# Altium schematic sheet P13_M1_SLA_CHARGER.SchDoc(P13_M1_SLA_CHARGER)
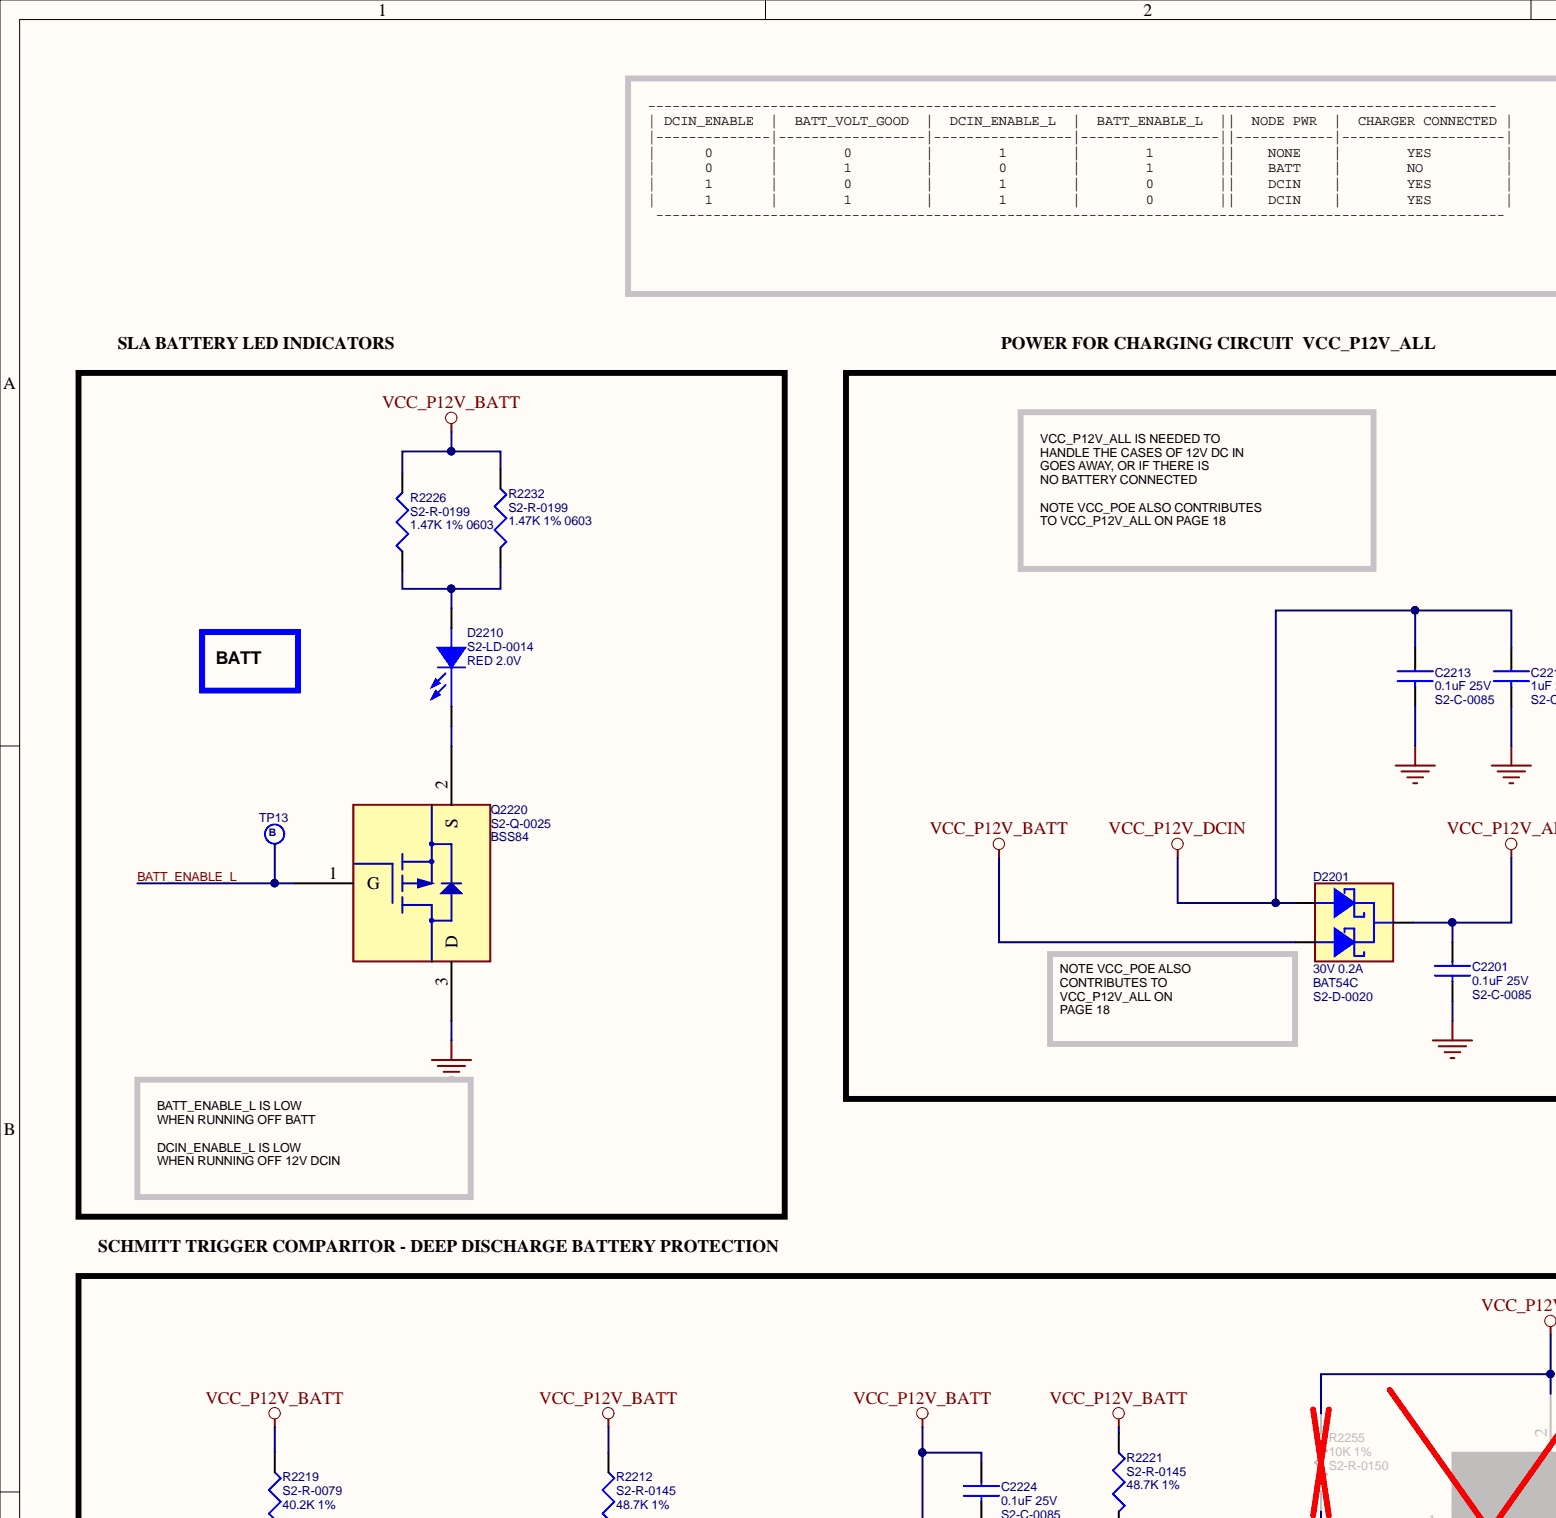
[diagram p0: P13_M1_SLA_CHARGER.SchDoc, top left]
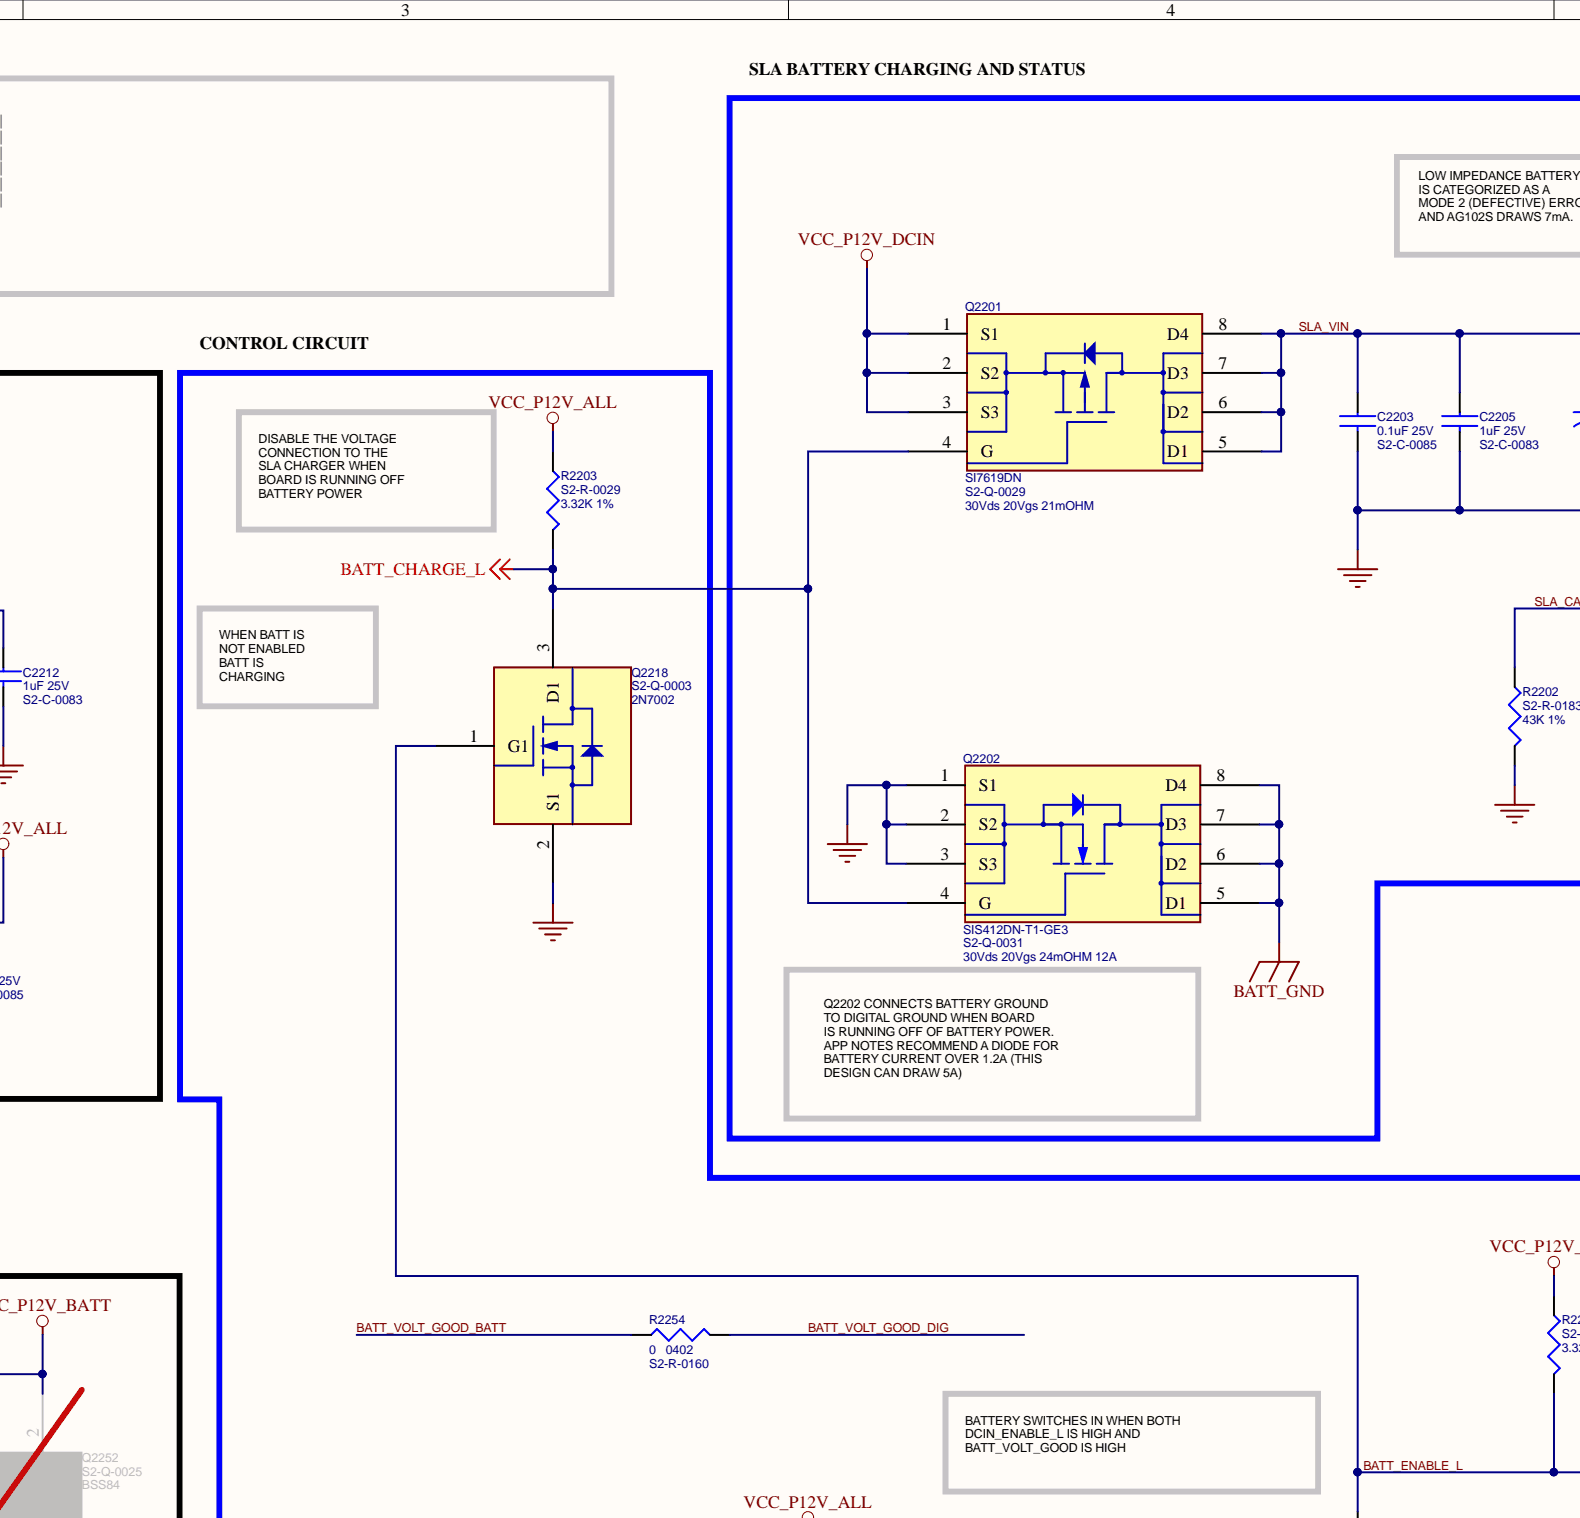
[diagram p1: P13_M1_SLA_CHARGER.SchDoc, top centre]
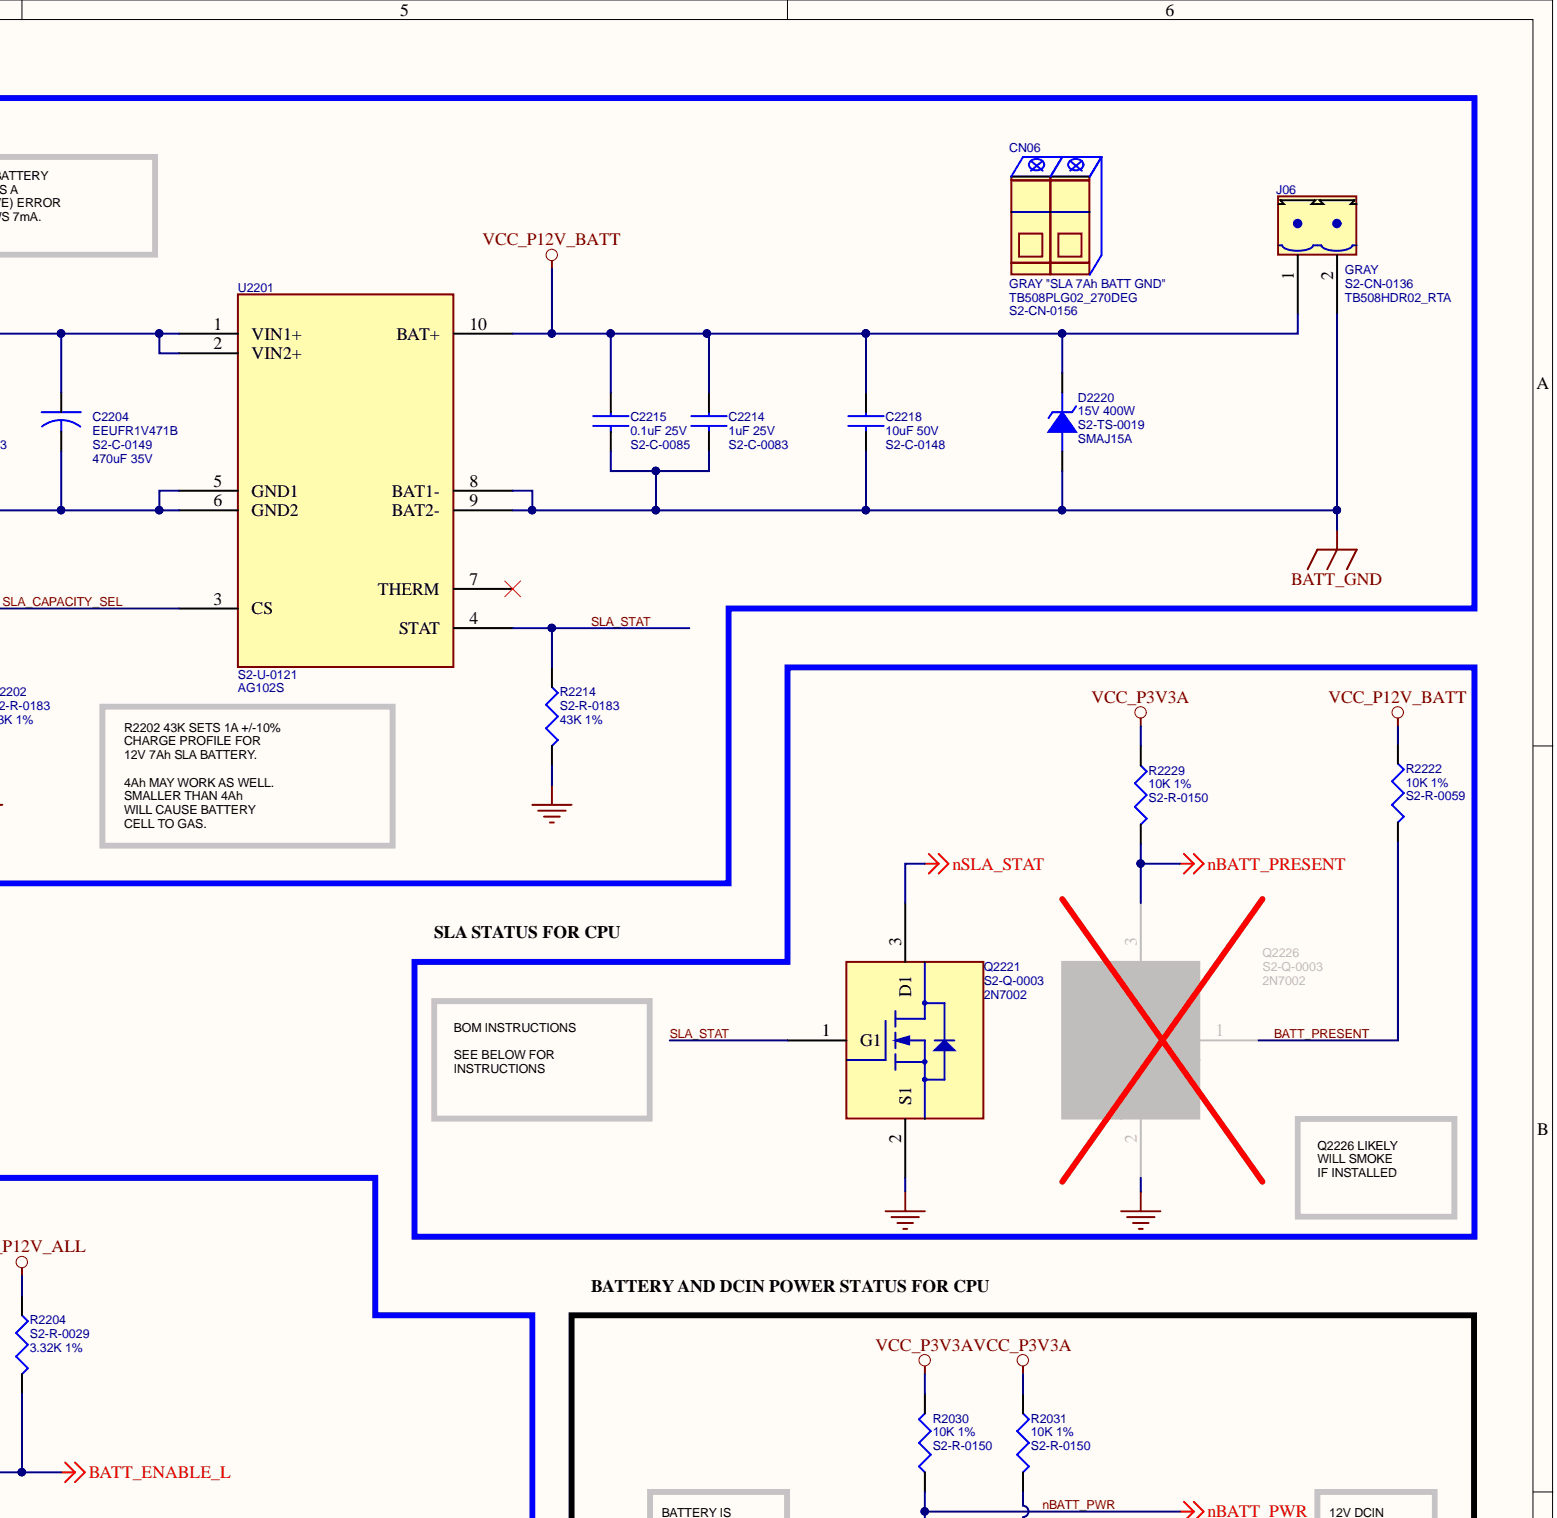
[diagram p2: P13_M1_SLA_CHARGER.SchDoc, top right]
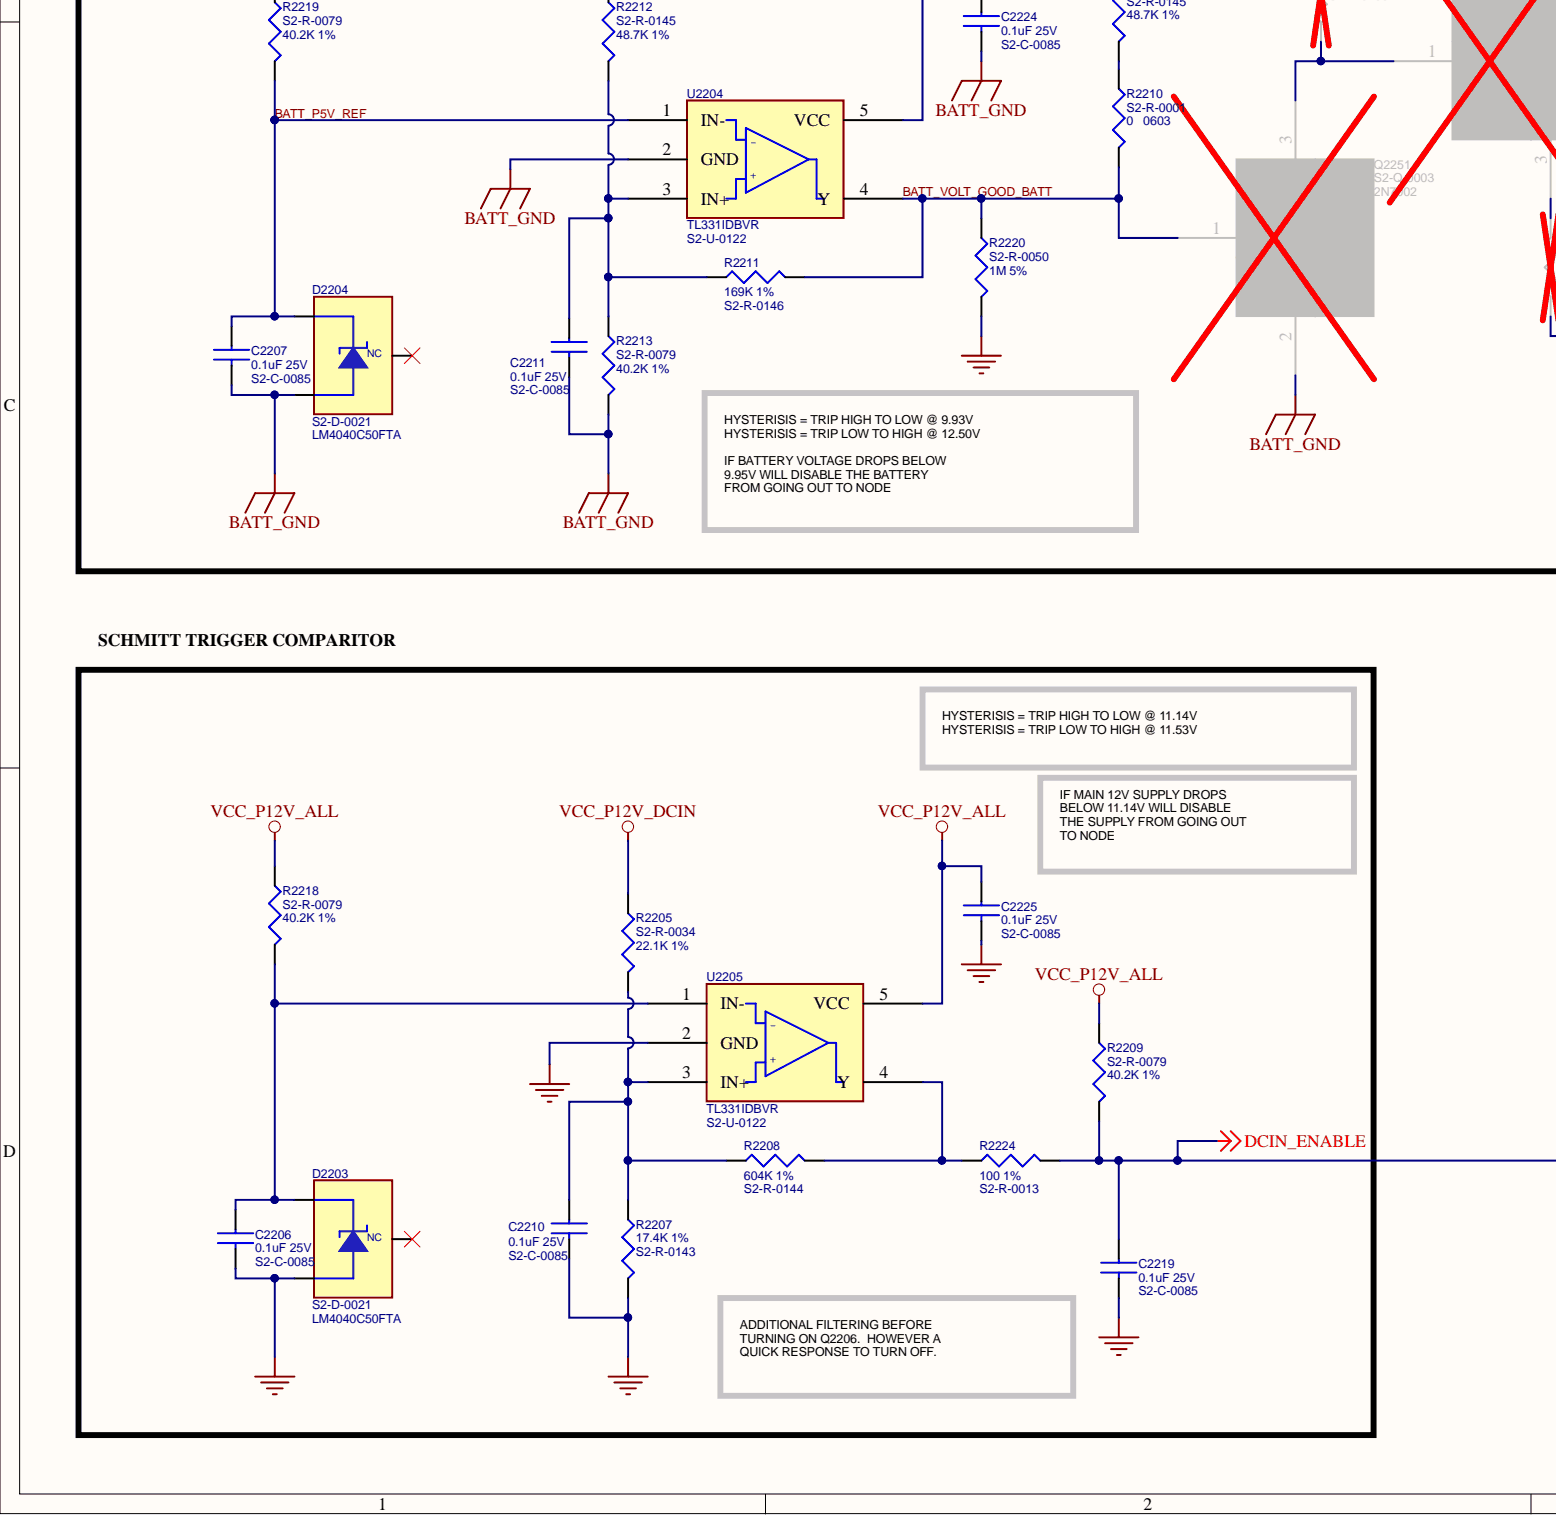
[diagram p3: P13_M1_SLA_CHARGER.SchDoc, bottom left]
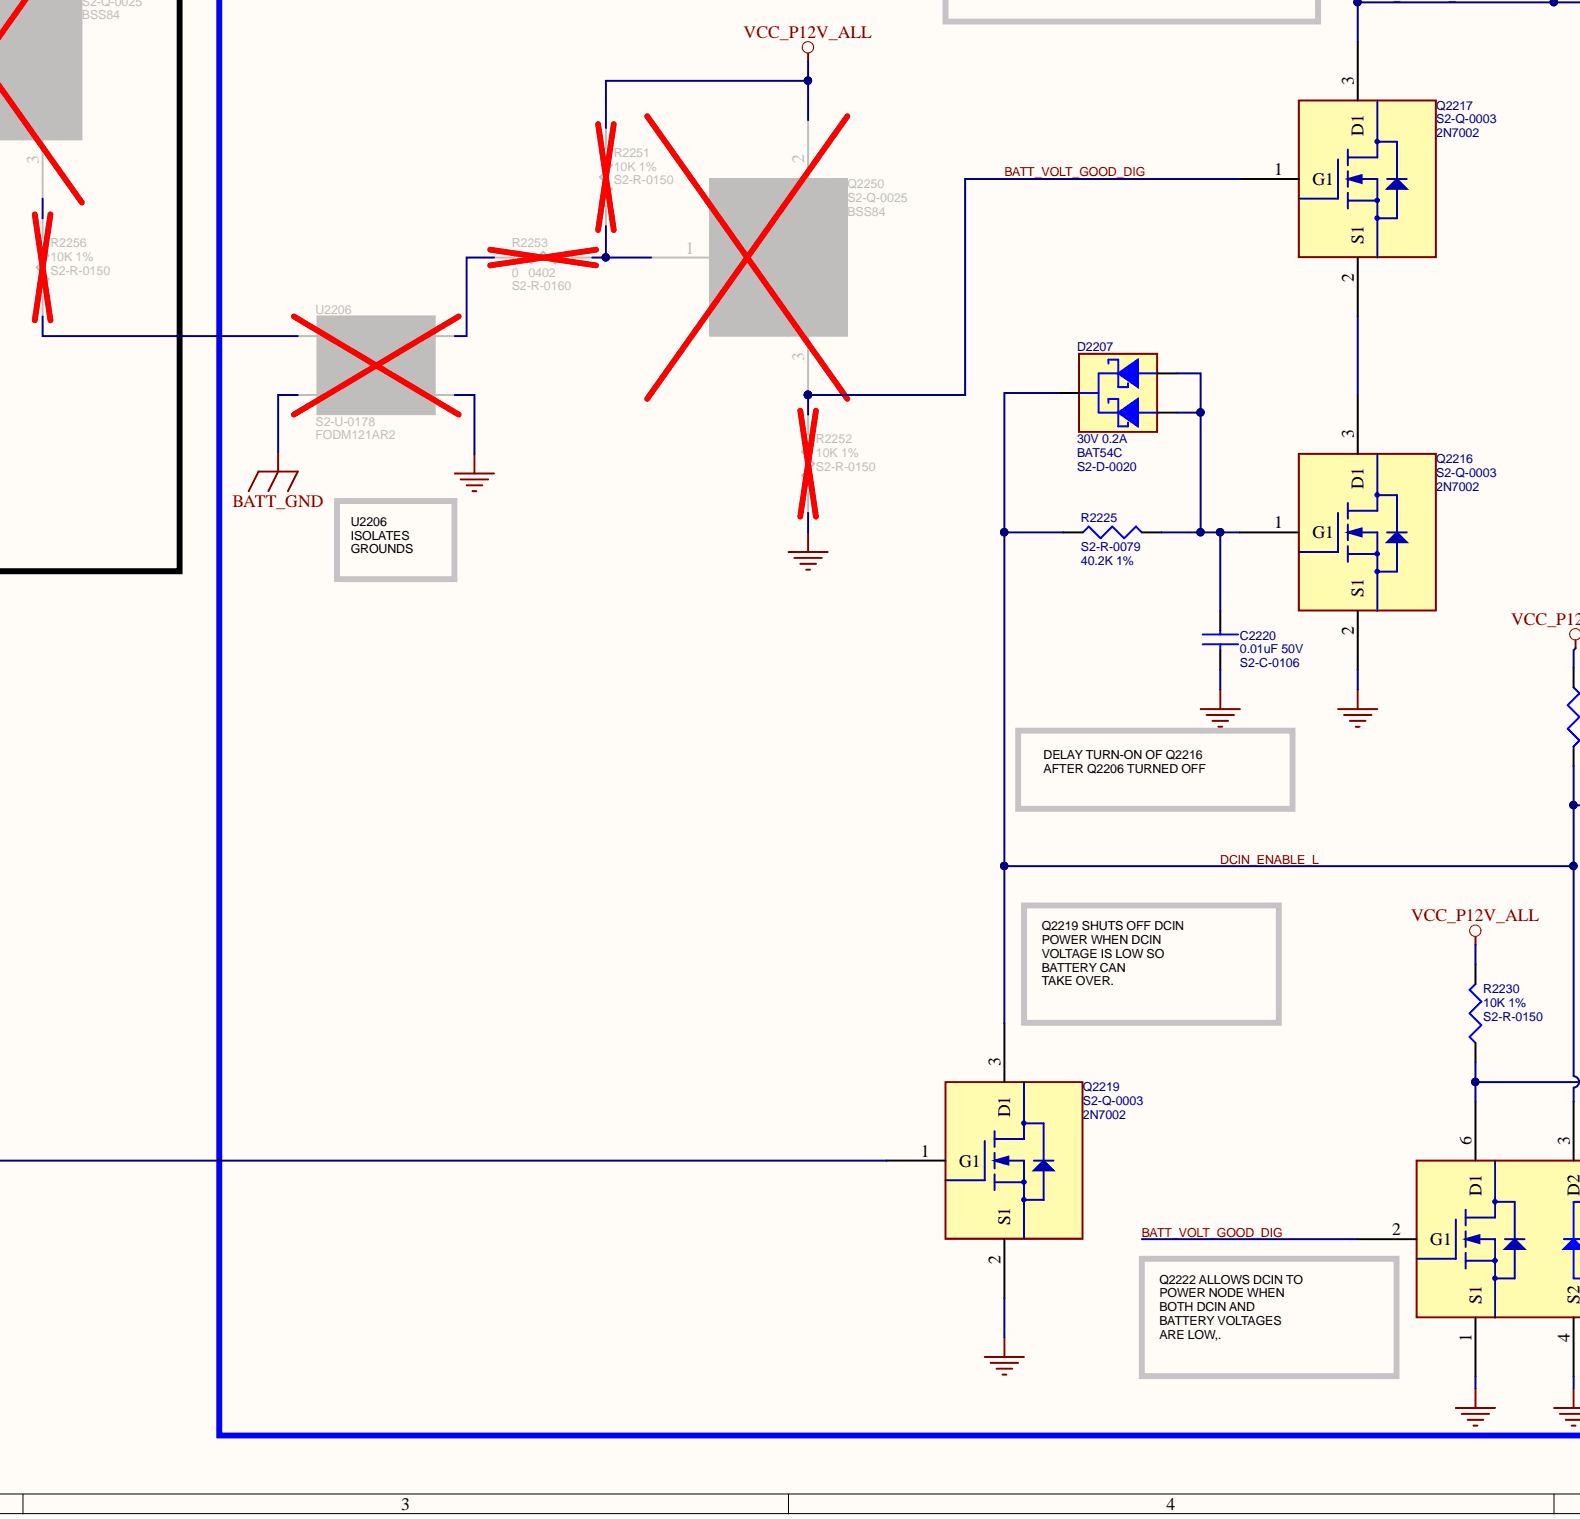
[diagram p4: P13_M1_SLA_CHARGER.SchDoc, bottom centre]
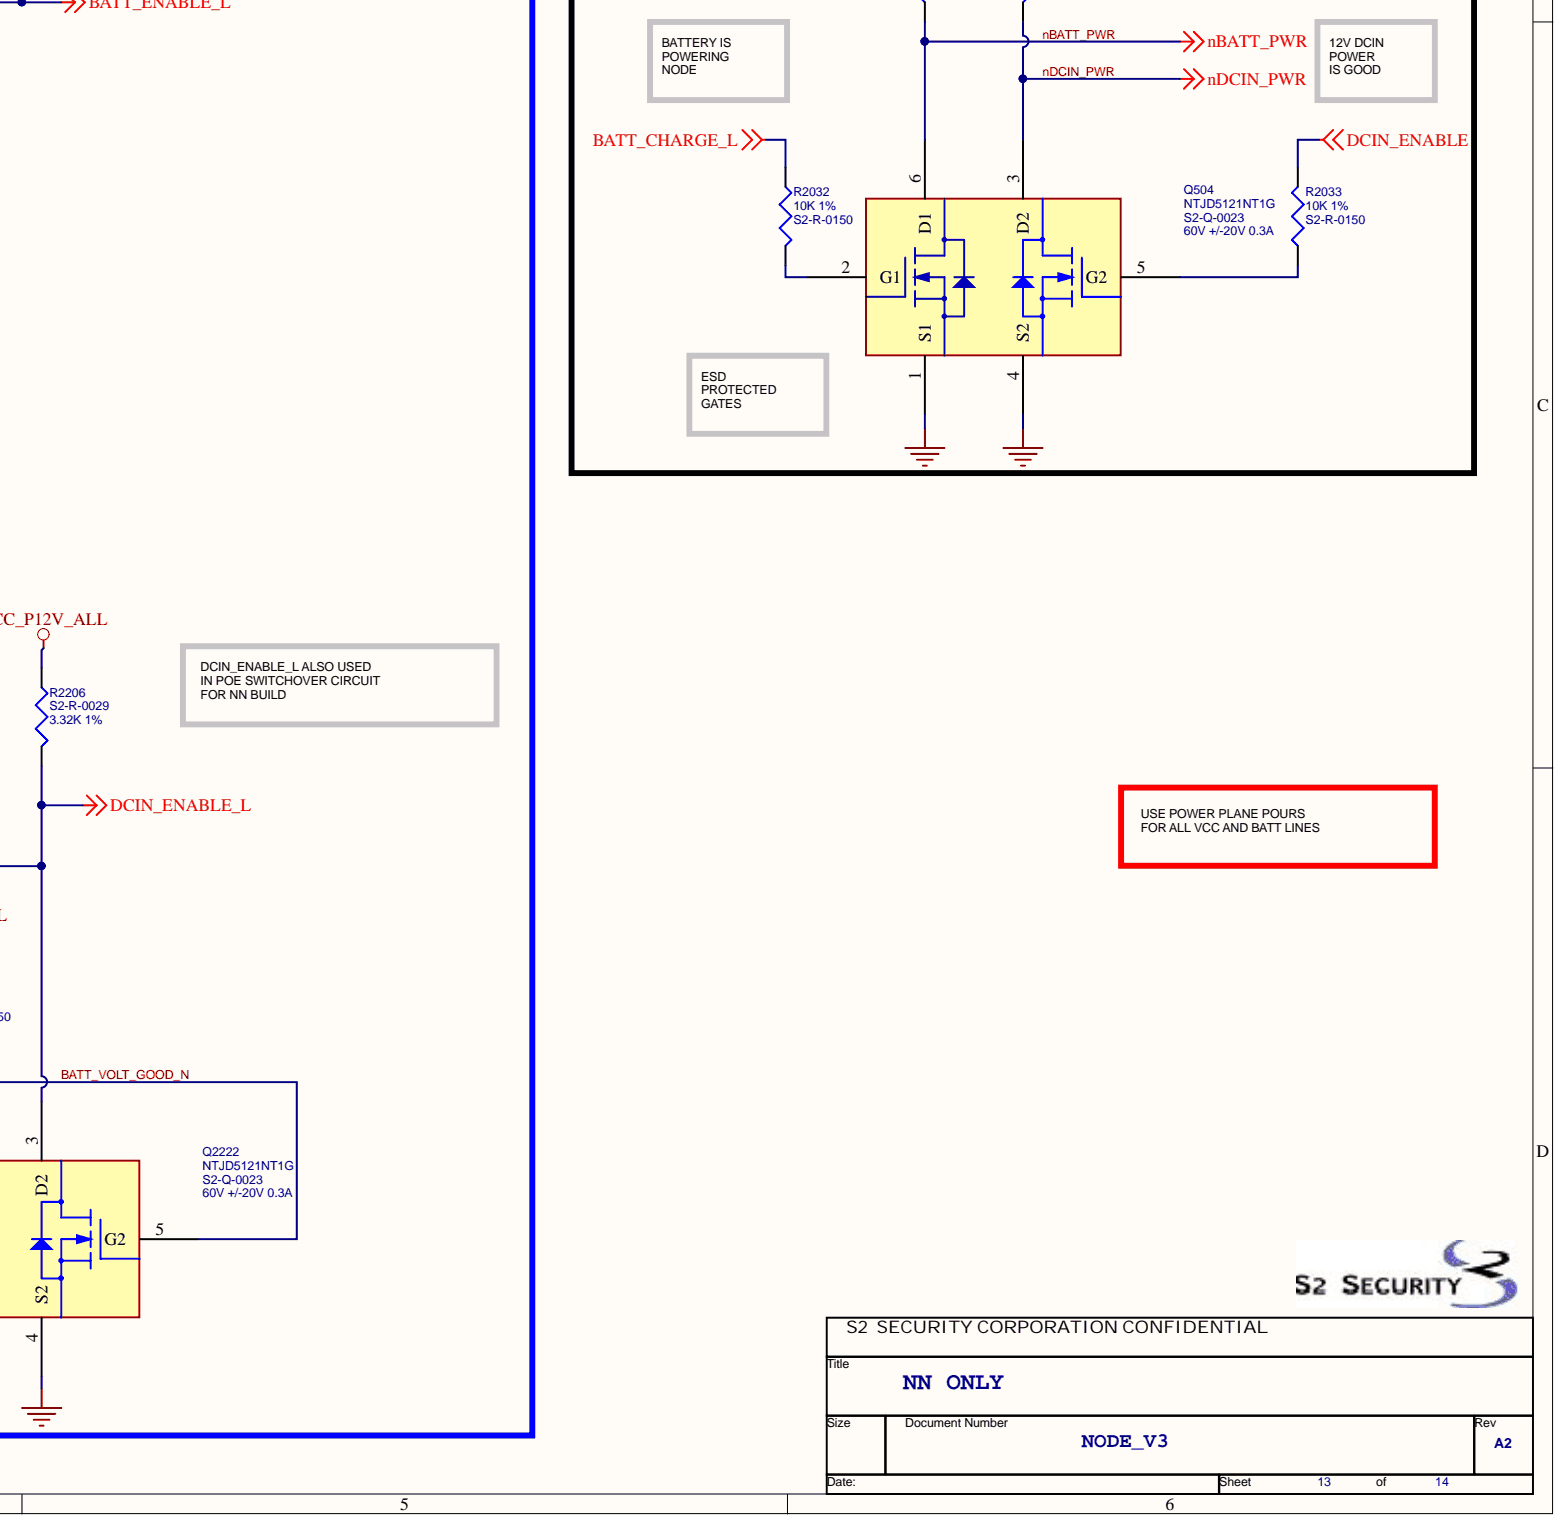
[diagram p5: P13_M1_SLA_CHARGER.SchDoc, bottom right]

## Parts
C2201  S2_PN: S2-C-0085  DESC: 0.1uF 25V  [CAPC1608X90N]  (hidden comment: C_0U1_25V_0603)
C2203  S2_PN: S2-C-0085  DESC: 0.1uF 25V  [CAPC1608X90N]  (hidden comment: C_0U1_25V_0603)
C2204  S2_PN: S2-C-0149  ATTR2: EEUFR1V471B  [CAP_EEU-FR1V471B]  (hidden comment: C_ALUM_470U_35V_RAD_5MM)
C2205  S2_PN: S2-C-0083  DESC: 1uF 25V  [CAPC1608X90N]  (hidden comment: C_1U_25V_0603)
C2206  S2_PN: S2-C-0085  DESC: 0.1uF 25V  [CAPC1608X90N]  (hidden comment: C_0U1_25V_0603)
C2207  S2_PN: S2-C-0085  DESC: 0.1uF 25V  [CAPC1608X90N]  (hidden comment: C_0U1_25V_0603)
C2210  S2_PN: S2-C-0085  DESC: 0.1uF 25V  [CAPC1608X90N]  (hidden comment: C_0U1_25V_0603)
C2211  S2_PN: S2-C-0085  DESC: 0.1uF 25V  [CAPC1608X90N]  (hidden comment: C_0U1_25V_0603)
C2212  S2_PN: S2-C-0083  DESC: 1uF 25V  [CAPC1608X90N]  (hidden comment: C_1U_25V_0603)
C2213  S2_PN: S2-C-0085  DESC: 0.1uF 25V  [CAPC1608X90N]  (hidden comment: C_0U1_25V_0603)
C2214  S2_PN: S2-C-0083  DESC: 1uF 25V  [CAPC1608X90N]  (hidden comment: C_1U_25V_0603)
C2215  S2_PN: S2-C-0085  DESC: 0.1uF 25V  [CAPC1608X90N]  (hidden comment: C_0U1_25V_0603)
C2218  S2_PN: S2-C-0148  DESC: 10uF 50V  [CAPC3216X180N]  (hidden comment: C_10U_50V_0805)
C2219  S2_PN: S2-C-0085  DESC: 0.1uF 25V  [CAPC1608X90N]  (hidden comment: C_0U1_25V_0603)
C2220  S2_PN: S2-C-0106  [CAPC1608X90N]  (hidden comment: C_0U01_50V_0603)
C2224  S2_PN: S2-C-0085  DESC: 0.1uF 25V  [CAPC1608X90N]  (hidden comment: C_0U1_25V_0603)
C2225  S2_PN: S2-C-0085  DESC: 0.1uF 25V  [CAPC1608X90N]  (hidden comment: C_0U1_25V_0603)
CN06  S2_PN: S2-CN-0156  DESC: TB508PLG02_270DEG  ATTR2: GRAY "SLA 7Ah BATT GND"  [N/A]  (hidden comment: CN_5MM08_02PLUG_270DEG_GRAY_SLA)
D2201  S2_PN: S2-D-0020  DESC: BAT54C  ATTR2: 30V 0.2A  [SOT95P310X140-3N]  (hidden comment: D_BAT54C_SCHOTTKY_DUAL_30V_0A2)
D2203  S2_PN: S2-D-0021  DESC: LM4040C50FTA  [SOT95P310X140-3N]  (hidden comment: D_LM4040C50FTA_SHUNT_REF_5V)
D2204  S2_PN: S2-D-0021  DESC: LM4040C50FTA  [SOT95P310X140-3N]  (hidden comment: D_LM4040C50FTA_SHUNT_REF_5V)
D2207  S2_PN: S2-D-0020  DESC: BAT54C  ATTR2: 30V 0.2A  [SOT95P310X140-3N]  (hidden comment: D_BAT54C_SCHOTTKY_DUAL_30V_0A2)
D2210  S2_PN: S2-LD-0014  ATTR: RED 2.0V  [LED_0805]  (hidden comment: LED_0805_RED)
D2220  S2_PN: S2-TS-0019  DESC: SMAJ15A  ATTR2: 15V 400W  [DOIM5026x229N]  (hidden comment: D_SMAJ15A_TVS_15V_400W)
J06  S2_PN: S2-CN-0136  PKG: TB508HDR02_RTA  ATTR2: GRAY  [Conn_5433668]  (hidden comment: CN_5MM08_02POS_HEADER_RTA_GRAY)
Q504  S2_PN: S2-Q-0023  DESC: NTJD5121NT1G  [SOT65x210x140x110-6N]  (hidden comment: Q_DUAL_NFET_NTJD5121N)
Q2201  S2_PN: S2-Q-0029  DESC: SI7619DN  ATTR2: 30Vds 20Vgs 21mOHM  [POWERPAK_1212X66X340X112X8N]  (hidden comment: Q_POWER_PFET_SI7619DN)
Q2202  S2_PN: S2-Q-0031  DESC: SIS412DN-T1-GE3  ATTR2: 30Vds 20Vgs 24mOHM 12A  [PowerPAK_330X330X120_COMMON]  (hidden comment: Q_POWER_NFET_SIS412DN)
Q2216  S2_PN: S2-Q-0003  DESC: 2N7002  [SOT95P310X140-3N]  (hidden comment: Q_NFET_2N7002)
Q2217  S2_PN: S2-Q-0003  DESC: 2N7002  [SOT95P310X140-3N]  (hidden comment: Q_NFET_2N7002)
Q2218  S2_PN: S2-Q-0003  DESC: 2N7002  [SOT95P310X140-3N]  (hidden comment: Q_NFET_2N7002)
Q2219  S2_PN: S2-Q-0003  DESC: 2N7002  [SOT95P310X140-3N]  (hidden comment: Q_NFET_2N7002)
Q2220  S2_PN: S2-Q-0025  DESC: BSS84  [SOT95P310X140-3N]  (hidden comment: Q_PFET_BSS84)
Q2221  S2_PN: S2-Q-0003  DESC: 2N7002  [SOT95P310X140-3N]  (hidden comment: Q_NFET_2N7002)
Q2222  S2_PN: S2-Q-0023  DESC: NTJD5121NT1G  ATTR2: 60V +/-20V 0.3A  [SOT65x210x140x110-6N]  (hidden comment: Q_DUAL_NFET_NTJD5121N)
Q2226  S2_PN: S2-Q-0003  DESC: 2N7002  [SOT95P310X140-3N]  (hidden comment: Q_NFET_2N7002)
Q2250  S2_PN: S2-Q-0025  DESC: BSS84  [SOT95P310X140-3N]  (hidden comment: Q_PFET_BSS84)
Q2251  S2_PN: S2-Q-0003  DESC: 2N7002  [SOT95P310X140-3N]  (hidden comment: Q_NFET_2N7002)
Q2252  S2_PN: S2-Q-0025  DESC: BSS84  [SOT95P310X140-3N]  (hidden comment: Q_PFET_BSS84)
R2030  S2_PN: S2-R-0150  DESC: 10K 1%  [RESC1005X40N]  (hidden comment: R_10K_0402)
R2031  S2_PN: S2-R-0150  DESC: 10K 1%  [RESC1005X40N]  (hidden comment: R_10K_0402)
R2032  S2_PN: S2-R-0150  DESC: 10K 1%  [RESC1005X40N]  (hidden comment: R_10K_0402)
R2033  S2_PN: S2-R-0150  DESC: 10K 1%  [RESC1005X40N]  (hidden comment: R_10K_0402)
R2202  S2_PN: S2-R-0183  DESC: 43K 1%  [RESC1608X55N]  (hidden comment: R_43K_0603)
R2203  S2_PN: S2-R-0029  DESC: 3.32K 1%  [RESC1608X55N]  (hidden comment: R_3K32_0603)
R2204  S2_PN: S2-R-0029  DESC: 3.32K 1%  [RESC1608X55N]  (hidden comment: R_3K32_0603)
R2205  S2_PN: S2-R-0034  DESC: 22.1K 1%  [RESC1608X55N]  (hidden comment: R_22K1_0603)
R2206  S2_PN: S2-R-0029  DESC: 3.32K 1%  [RESC1608X55N]  (hidden comment: R_3K32_0603)
R2207  S2_PN: S2-R-0143  DESC: 17.4K 1%  [RESC1608X55N]  (hidden comment: R_17K4_0603)
R2208  S2_PN: S2-R-0144  DESC: 604K 1%  [RESC1608X55N]  (hidden comment: R_604K_0603)
R2209  S2_PN: S2-R-0079  DESC: 40.2K 1%  [RESC1608X55N]  (hidden comment: R_40K2_0603)
R2210  S2_PN: S2-R-0001  [RESC1608X55N]  (hidden comment: R_0_0603)
R2211  S2_PN: S2-R-0146  DESC: 169K 1%  [RESC1608X55N]  (hidden comment: R_169K_0603)
R2212  S2_PN: S2-R-0145  DESC: 48.7K 1%  [RESC1608X55N]  (hidden comment: R_48K7_0603)
R2213  S2_PN: S2-R-0079  DESC: 40.2K 1%  [RESC1608X55N]  (hidden comment: R_40K2_0603)
R2214  S2_PN: S2-R-0183  DESC: 43K 1%  [RESC1608X55N]  (hidden comment: R_43K_0603)
R2218  S2_PN: S2-R-0079  DESC: 40.2K 1%  [RESC1608X55N]  (hidden comment: R_40K2_0603)
R2219  S2_PN: S2-R-0079  DESC: 40.2K 1%  [RESC1608X55N]  (hidden comment: R_40K2_0603)
R2220  S2_PN: S2-R-0050  DESC: 1M 5%  [RESC1608X55N]  (hidden comment: R_1M_0603_5percent)
R2221  S2_PN: S2-R-0145  DESC: 48.7K 1%  [RESC1608X55N]  (hidden comment: R_48K7_0603)
R2222  S2_PN: S2-R-0059  DESC: 10K 1%  [RESC1608X55N]  (hidden comment: R_10K_0603)
R2224  S2_PN: S2-R-0013  DESC: 100 1%  [RESC1608X55N]  (hidden comment: R_100_0603)
R2225  S2_PN: S2-R-0079  DESC: 40.2K 1%  [RESC1608X55N]  (hidden comment: R_40K2_0603)
R2226  S2_PN: S2-R-0199  [RESC1608X55N]  (hidden comment: R_1K47_0603)
R2229  S2_PN: S2-R-0150  DESC: 10K 1%  [RESC1005X40N]  (hidden comment: R_10K_0402)
R2230  S2_PN: S2-R-0150  DESC: 10K 1%  [RESC1005X40N]  (hidden comment: R_10K_0402)
R2232  S2_PN: S2-R-0199  DESC: 1.47K 1% 0603  [RESC1608X55N]  (hidden comment: R_1K47_0603)
R2251  S2_PN: S2-R-0150  DESC: 10K 1%  [RESC1005X40N]  (hidden comment: R_10K_0402)
R2252  S2_PN: S2-R-0150  DESC: 10K 1%  [RESC1005X40N]  (hidden comment: R_10K_0402)
R2253  S2_PN: S2-R-0160  DESC: 0   0402  [RESC1005X40N]  (hidden comment: R_0_0402)
R2254  S2_PN: S2-R-0160  DESC: 0   0402  [RESC1005X40N]  (hidden comment: R_0_0402)
R2255  S2_PN: S2-R-0150  DESC: 10K 1%  [RESC1005X40N]  (hidden comment: R_10K_0402)
R2256  S2_PN: S2-R-0150  DESC: 10K 1%  [RESC1005X40N]  (hidden comment: R_10K_0402)
TP13  TP_SMT_TEST_POINT_BOTTOM  [TP35_SMT]  (value not drawn)
U2201  S2_PN: S2-U-0121  DESC: AG102S  [CONN_AG102S]  (hidden comment: U_AG102S_SLA_CHARGER)
U2204  DESC: TL331IDBVR  [SOT95P280X145-5N]  (hidden comment: U_TL331IDBVR_DIFF_COMPARATOR)
U2205  DESC: TL331IDBVR  [SOT95P280X145-5N]  (hidden comment: U_TL331IDBVR_DIFF_COMPARATOR)
U2206  S2_PN: S2-U-0178  DESC: FODM121AR2  [Optocoupler_FODM121AR2]  (hidden comment: U_PHOTOTRANS_OPTOCPL_FODM121AR2)

## Nets
BATT_CHARGE_L: Q2201.4(G) Q2202.4(G) Q2218.3 R2032.1 R2203.2
BATT_GND: C2207.2 C2211.2 C2214.2 C2215.2 C2218.2 C2224.2 D2204.2 D2220.1 J06.2 Q2202.5(D1) Q2202.6(D2) Q2202.7(D3) Q2202.8(D4) Q2251.2 R2213.2 U2201.8(BAT1-) U2201.9(BAT2-) U2204.2(GND) U2206.2
DCIN_ENABLE: C2219.1 Q2219.1 R2033.2 R2209.2 R2224.2
GND: C2201.2 C2203.2 C2204.2 C2205.2 C2206.2 C2210.2 C2212.2 C2213.2 C2219.2 C2220.2 C2225.2 D2203.2 Q504.1(S1) Q504.4(S2) Q2202.1(S1) Q2202.2(S2) Q2202.3(S3) Q2216.2 Q2218.2 Q2219.2 Q2220.3 Q2221.2 Q2222.1(S1) Q2222.4(S2) Q2226.2 R2202.2 R2207.2 R2214.2 R2220.2 R2252.2 U2201.5(GND1) U2201.6(GND2) U2205.2(GND) U2206.3
nBATT_PRESENT: Q2226.3 R2229.2
nSLA_STAT: Q2221.3
VCC_P3V3A: R2030.1 R2031.1 R2229.1
VCC_P12V_ALL: C2201.1 C2225.1 D2201.3 Q2250.2 R2203.1 R2204.1 R2206.1 R2209.1 R2218.1 R2230.1 R2251.1 U2205.5(VCC)
VCC_P12V_BATT: C2214.1 C2215.1 C2218.1 C2224.1 D2201.2 D2220.2 J06.1 Q2252.2 R2212.1 R2219.1 R2221.1 R2222.1 R2226.1 R2232.1 R2255.1 U2201.10(BAT+) U2204.5(VCC)
VCC_P12V_DCIN: C2212.1 C2213.1 D2201.1 Q2201.1(S1) Q2201.2(S2) Q2201.3(S3) R2205.1
BATT_ENABLE_L: Q2217.3 Q2218.1 Q2220.1 R2204.2 TP13.1
BATT_P5V_REF: C2207.1 D2204.1 R2219.2 U2204.1(IN-)
BATT_PRESENT: Q2226.1 R2222.2
BATT_VOLT_GOOD_BATT: Q2251.1 R2210.2 R2211.2 R2220.1 R2254.1 U2204.4(Y)
BATT_VOLT_GOOD_DIG: Q2217.1 Q2222.2(G1) Q2250.3 R2252.1 R2254.2
BATT_VOLT_GOOD_N: Q2222.5(G2) Q2222.6(D1) R2230.2
DCIN_ENABLE_L: D2207.3 Q2219.3 Q2222.3(D2) R2206.2 R2225.1
nBATT_PWR: Q504.6(D1) R2030.2
nDCIN_PWR: Q504.3(D2) R2031.2
SLA_CAPACITY_SEL: R2202.1 U2201.3(CS)
SLA_STAT: Q2221.1 R2214.1 U2201.4(STAT)
SLA_VIN: C2203.1 C2204.1 C2205.1 Q2201.5(D1) Q2201.6(D2) Q2201.7(D3) Q2201.8(D4) U2201.1(VIN1+) U2201.2(VIN2+)
NetC2206_1: C2206.1 D2203.1 R2218.2 U2205.1(IN-)
NetC2210_1: C2210.1 R2205.2 R2207.1 R2208.1 U2205.3(IN+)
NetC2211_1: C2211.1 R2211.1 R2212.2 R2213.1 U2204.3(IN+)
NetC2220_1: C2220.1 D2207.1 D2207.2 Q2216.1 R2225.2
NetD2210_1: D2210.1 R2226.2 R2232.2
NetD2210_2: D2210.2 Q2220.2
NetQ504_2: Q504.2(G1) R2032.2
NetQ504_5: Q504.5(G2) R2033.1
NetQ2216_3: Q2216.3 Q2217.2
NetQ2250_1: Q2250.1 R2251.2 R2253.2
NetQ2251_3: Q2251.3 Q2252.1 R2255.2
NetQ2252_3: Q2252.3 R2256.1
NetR2208_2: R2208.2 R2224.1 U2205.4(Y)
NetR2210_1: R2210.1 R2221.2
NetR2253_1: R2253.1 U2206.4
NetR2256_2: R2256.2 U2206.1

Not connected: D2203 3; D2204 3; U2201 7(THERM)

## Library data of the parts
C2201 C2203 C2206 C2207 C2210 C2211 C2213 C2215 C2219 C2224 C2225 = C_0U1_25V_0603: PKG: CC0603IPC; ATTR: X7R 10%; Library Name: NODE_V2_M1.SCHLIB; Component Kind: Standard
C2204 = C_ALUM_470U_35V_RAD_5MM: PKG: TH_DLS_10_17_5MM; DESC: 470uF 35V; ATTR: CAP AL 470UF 35V, 20%, 8000 HRS 105C TH, ESR=0.028; Library Name: NODE_V2_M1.SCHLIB; Component Kind: Standard
C2205 C2212 C2214 = C_1U_25V_0603: PKG: CC0603IPC; ATTR: X7R 10%; Library Name: NODE_V2_M1.SCHLIB; Component Kind: Standard
C2218 = C_10U_50V_0805: PKG: CC0805IPC; ATTR: X5R 10%; Library Name: NODE_V2_M1.SCHLIB; Component Kind: Standard
C2220 = C_0U01_50V_0603: PKG: CC0603IPC; DESC: 0.01uF 50V; ATTR: X7R 10%; Library Name: NODE_V2_M1.SCHLIB; Component Kind: Standard
CN06 = CN_5MM08_02PLUG_270DEG_GRAY_SLA: PKG: TB508PLUG02_180DEG; ATTR: PLUG TERM BLK 5.08MM 2POS 270DEG GRAY; Library Name: NODE_V2_M1.SCHLIB; Component Kind: Standard
D2201 D2207 = D_BAT54C_SCHOTTKY_DUAL_30V_0A2: PKG: SOT-23-3; ATTR: DIODE DUAL SCHOTTKY 30V 200 MA COMMON CATHODE; Library Name: NODE_V2_M1.SCHLIB; Component Kind: Standard
D2203 D2204 = D_LM4040C50FTA_SHUNT_REF_5V: PKG: SOT-23-321; ATTR: DIODE 5V SHUNT REFERENCE 0.5% 15mA SOT-23; Library Name: NODE_V2_M1.SCHLIB; Component Kind: Standard
D2210 = LED_0805_RED: DESC: LED 0805 SUPER RED 2.0V 54MCD; PKG: LED_0805; Library Name: NODE_V2_M1.SCHLIB; Component Kind: Standard
D2220 = D_SMAJ15A_TVS_15V_400W: PKG: SMA; ATTR: TVS UNI-DIR 15V 400W; Library Name: NODE_V2_M1.SCHLIB; Component Kind: Standard
J06 = CN_5MM08_02POS_HEADER_RTA_GRAY: ATTR: HEADER TERM BLK 5.08MM 2POS RTA GRAY; Library Name: NODE_V2_M1.SCHLIB; DESC: TB508HDR02_RTA; Component Kind: Standard
Q504 = Q_DUAL_NFET_NTJD5121N: PKG: SC-88; ATTR2: 60V +/-20V 0.3A; ATTR: MOSFET DUAL N-CHAN 60V +/-20V 0.3A; Library Name: NODE_V2_M1.SCHLIB; Component Kind: Standard
Q2201 = Q_POWER_PFET_SI7619DN: PKG: PowerPAK_1212-8; ATTR: MOSFET P-CH 21mOHM 30V 24A PowerPAK 1212-8; Library Name: NODE_V2_M1.SCHLIB; Component Kind: Standard
Q2202 = Q_POWER_NFET_SIS412DN: PKG: PowerPAK_1212-8; ATTR: MOSFET N-CH 24mOHM 30V 12A PowerPAK 1212-8; Library Name: NODE_V2_M1.SCHLIB; Component Kind: Standard
Q2216-Q2219 Q2221 Q2226 Q2251 = Q_NFET_2N7002: PKG: SOT-23; ATTR: MOSFET N-CHAN 60V 7.5OHM; Library Name: NODE_V2_M1.SCHLIB; Component Kind: Standard
Q2220 Q2250 Q2252 = Q_PFET_BSS84: PKG: SOT-23; ATTR2: -50V 0.13A 10OHM; ATTR: MOSFET P-CH 10 OHM -50V 0.13A SOT-23; Library Name: NODE_V2_M1.SCHLIB; Component Kind: Standard
Q2222 = Q_DUAL_NFET_NTJD5121N: PKG: SC-88; ATTR: MOSFET DUAL N-CHAN 60V +/-20V 0.3A; Library Name: NODE_V2_M1.SCHLIB; Component Kind: Standard
R2030-R2033 R2229 R2230 R2251 R2252 R2255 R2256 = R_10K_0402: PKG: CC0402IPC; ATTR: 1/16W; Library Name: NODE_V2_M1.SCHLIB; Component Kind: Standard
R2202 R2214 = R_43K_0603: PKG: CC0603IPC; ATTR: RES 43K OHM 1/10W 1% 0603; Library Name: NODE_V2_M1.SCHLIB; Component Kind: Standard
R2203 R2204 R2206 = R_3K32_0603: PKG: CC0603IPC; ATTR: RES 3.32K OHM 1/10W 1% 0603; Library Name: NODE_V2_M1.SCHLIB; Component Kind: Standard
R2205 = R_22K1_0603: PKG: CC0603IPC; ATTR: RES 22.1K OHM 1/10W 1% 0603; Library Name: NODE_V2_M1.SCHLIB; Component Kind: Standard
R2207 = R_17K4_0603: PKG: CC0603IPC; ATTR: RES 17.4K OHM 1/10W 1% 0603; Library Name: NODE_V2_M1.SCHLIB; Component Kind: Standard
R2208 = R_604K_0603: PKG: CC0603IPC; ATTR: RES 604K OHM 1/10W 1% 0603; Library Name: NODE_V2_M1.SCHLIB; Component Kind: Standard
R2209 R2213 R2218 R2219 R2225 = R_40K2_0603: PKG: CC0603IPC; ATTR: RES 40.2K OHM 1/10W 1% 0603; Library Name: NODE_V2_M1.SCHLIB; Component Kind: Standard
R2210 = R_0_0603: PKG: CC0603IPC; DESC: 0   0603; ATTR: 1/10W 50mohm MAX; Library Name: NODE_V2_M1.SCHLIB; Component Kind: Standard
R2211 = R_169K_0603: PKG: CC0603IPC; ATTR: RES 169K OHM 1/10W 1% 0603; Library Name: NODE_V2_M1.SCHLIB; Component Kind: Standard
R2212 R2221 = R_48K7_0603: PKG: CC0603IPC; ATTR: RES 48.7K OHM 1/10W 1% 0603; Library Name: NODE_V2_M1.SCHLIB; Component Kind: Standard
R2220 = R_1M_0603_5percent: PKG: CC0603IPC; ATTR: 1/10W; Library Name: NODE_V2_M1.SCHLIB; Component Kind: Standard
R2222 = R_10K_0603: PKG: CC0603IPC; ATTR: 1/10W; Library Name: NODE_V2_M1.SCHLIB; Component Kind: Standard
R2224 = R_100_0603: PKG: CC0603IPC; ATTR: 1/10W; Library Name: NODE_V2_M1.SCHLIB; Component Kind: Standard
R2226 = R_1K47_0603: PKG: CC0603IPC; DESC: 1.47K 1% 0603; ATTR: RES 1.47K OHM 1/10W 1% 0603; Library Name: NODE_V2_M1.SCHLIB; Component Kind: Standard
R2232 = R_1K47_0603: PKG: CC0603IPC; ATTR: RES 1.47K OHM 1/10W 1% 0603; Library Name: NODE_V2_M1.SCHLIB; Component Kind: Standard
R2253 R2254 = R_0_0402: PKG: CC0402IPC; ATTR: 1/16W  50mohm MAX; Library Name: NODE_V2_M1.SCHLIB; Component Kind: Standard
TP13 = TP_SMT_TEST_POINT_BOTTOM: S2_PN: S2-TP-0003; PKG: TEST-PT-TPB; DESC: TEST POINT; ATTR: SMT BOTTOM SIDE TEST POINT; Library Name: NODE_V2_M1.SCHLIB; DNP: DNP; Component Kind: Standard
U2201 = U_AG102S_SLA_CHARGER: PKG: SIL-10-SLA; ATTR: MODULE - INTELLIGENT SEALED LEAD ACID BATTERY CHARGER (SLA); Library Name: NODE_V2_M1.SCHLIB; Component Kind: Standard
U2204 U2205 = U_TL331IDBVR_DIFF_COMPARATOR: S2_PN: S2-U-0122; PKG: SOT-23-5; ATTR: IC TL331 SINGLE DIFFERENTIAL COMPARATOR; Library Name: NODE_V2_M1.SCHLIB; Component Kind: Standard
U2206 = U_PHOTOTRANS_OPTOCPL_FODM121AR2: PKG: SMD4_7MMX3MM84; ATTR: IC PHOTOTRANSISTOR OPTOCOUPLER; Library Name: NODE_V2_M1.SCHLIB; Component Kind: Standard
